# Altium schematic sheet VGA.SchDoc
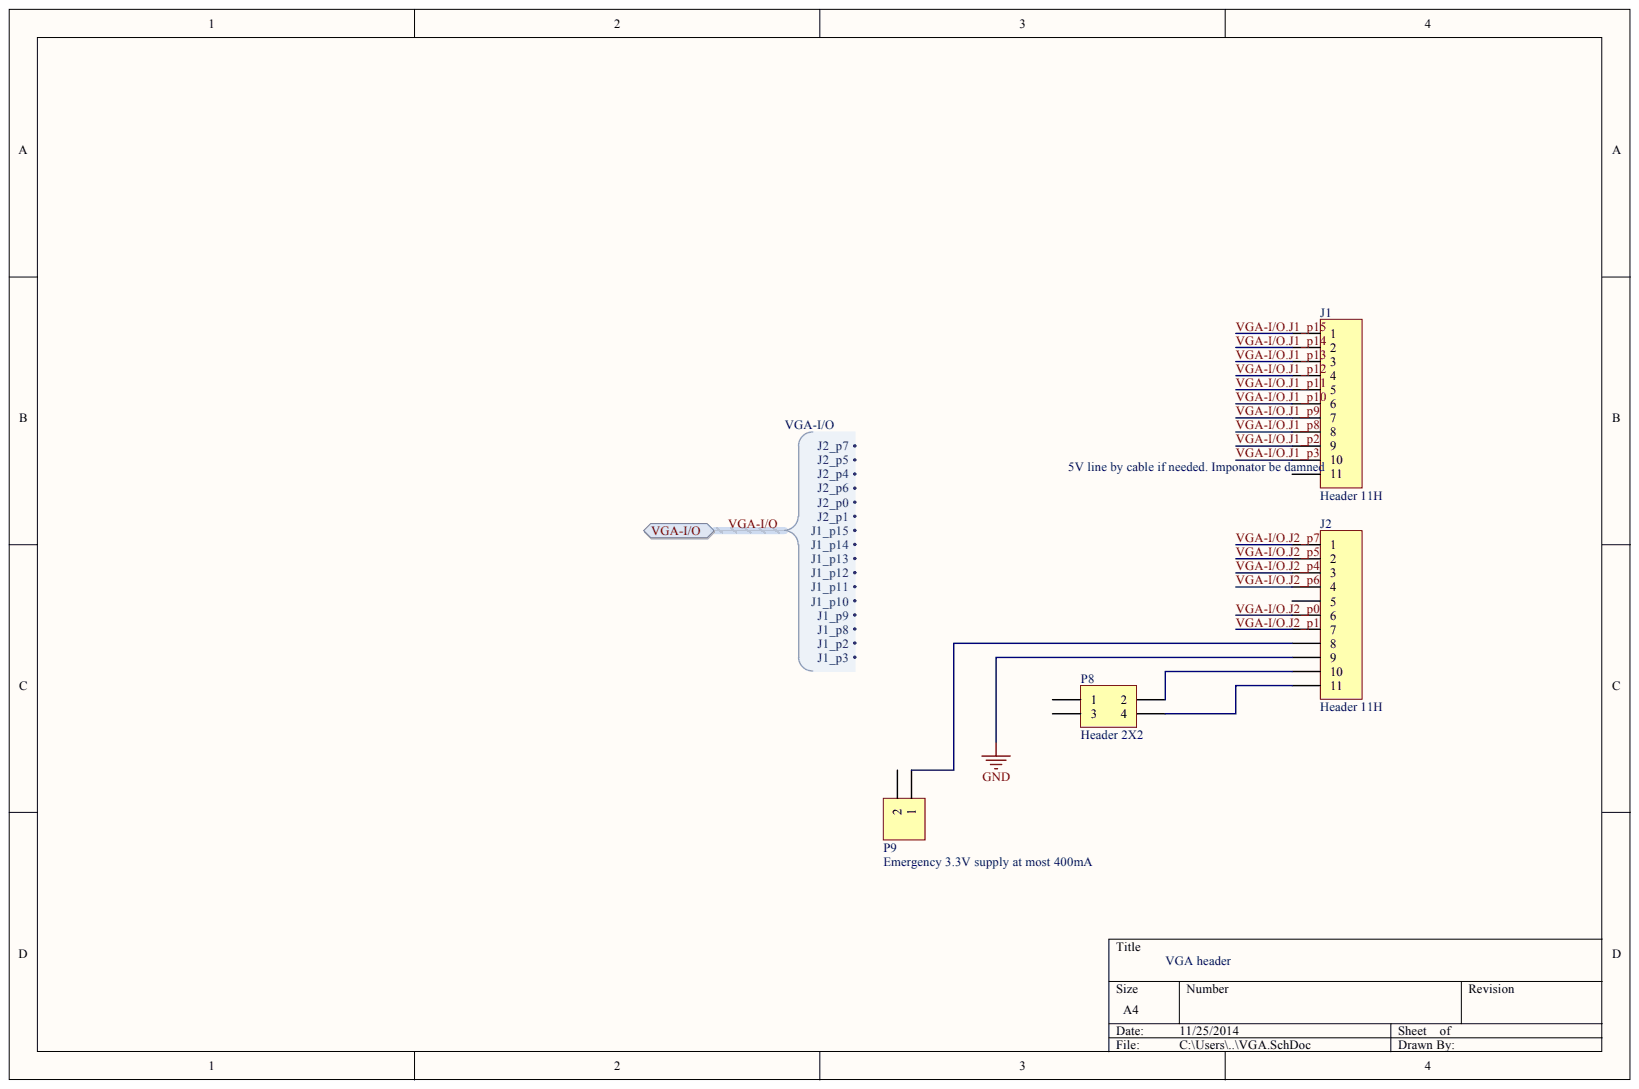
[diagram p0: VGA.SchDoc]

## Parts
J1  Header 11H  [HDR1X11H]
J2  Header 11H  [HDR1X11H]
P8  Header 2X2  [HDR2X2]
P9  Emergency 3.3V supply at most 400mA  [HDR1X2]

## Ports
VGA-I/O, VGA-I/O.J1_P2, VGA-I/O.J1_P3, VGA-I/O.J1_P8, VGA-I/O.J1_P9, VGA-I/O.J1_P10, VGA-I/O.J1_P11, VGA-I/O.J1_P12, VGA-I/O.J1_P13, VGA-I/O.J1_P14, VGA-I/O.J1_P15, VGA-I/O.J2_P0, VGA-I/O.J2_P1, VGA-I/O.J2_P4, VGA-I/O.J2_P5, VGA-I/O.J2_P6, VGA-I/O.J2_P7

## Nets
GND: J2.9
VGA-I/O.J1_P2: J1.9 ; port VGA-I/O
VGA-I/O.J1_P3: J1.10 ; port VGA-I/O
VGA-I/O.J1_P8: J1.8 ; port VGA-I/O
VGA-I/O.J1_P9: J1.7 ; port VGA-I/O
VGA-I/O.J1_P10: J1.6 ; port VGA-I/O
VGA-I/O.J1_P11: J1.5 ; port VGA-I/O
VGA-I/O.J1_P12: J1.4 ; port VGA-I/O
VGA-I/O.J1_P13: J1.3 ; port VGA-I/O
VGA-I/O.J1_P14: J1.2 ; port VGA-I/O
VGA-I/O.J1_P15: J1.1 ; port VGA-I/O
VGA-I/O.J2_P0: J2.6 ; port VGA-I/O
VGA-I/O.J2_P1: J2.7 ; port VGA-I/O
VGA-I/O.J2_P4: J2.3 ; port VGA-I/O
VGA-I/O.J2_P5: J2.2 ; port VGA-I/O
VGA-I/O.J2_P6: J2.4 ; port VGA-I/O
VGA-I/O.J2_P7: J2.1 ; port VGA-I/O
NetJ2_8: J2.8 P9.1
NetJ2_10: J2.10 P8.2
NetJ2_11: J2.11 P8.4

Not connected: J1 11; J2 5; P8 1 3; P9 2

## Library data of the parts
J1 J2 = Header 11H: Header, 11-Pin, Right Angle; Component Kind: Standard; LatestRevisionDate: 17-Jul-2002; LatestRevisionNote: Re-released for DXP Platform.; Library Name: Miscellaneous Connectors.IntLib; Signal Integrity: Connector; Publisher: Altium Limited
P8 = Header 2X2: Header, 2-Pin, Dual row; Component Kind: Standard; LatestRevisionDate: 17-Jul-2002; LatestRevisionNote: Re-released for DXP Platform.; Library Name: Miscellaneous Connectors.IntLib; Signal Integrity: Connector; Publisher: Altium Limited
P9 = Header 2: Header, 2-Pin; Component Kind: Standard; LatestRevisionDate: 17-Jul-2002; LatestRevisionNote: Re-released for DXP Platform.; Library Name: Miscellaneous Connectors.IntLib; Signal Integrity: Connector; Publisher: Altium Limited
